# Altium schematic sheet Transistorverstaerker.SchDoc(Transistorverstaerker)
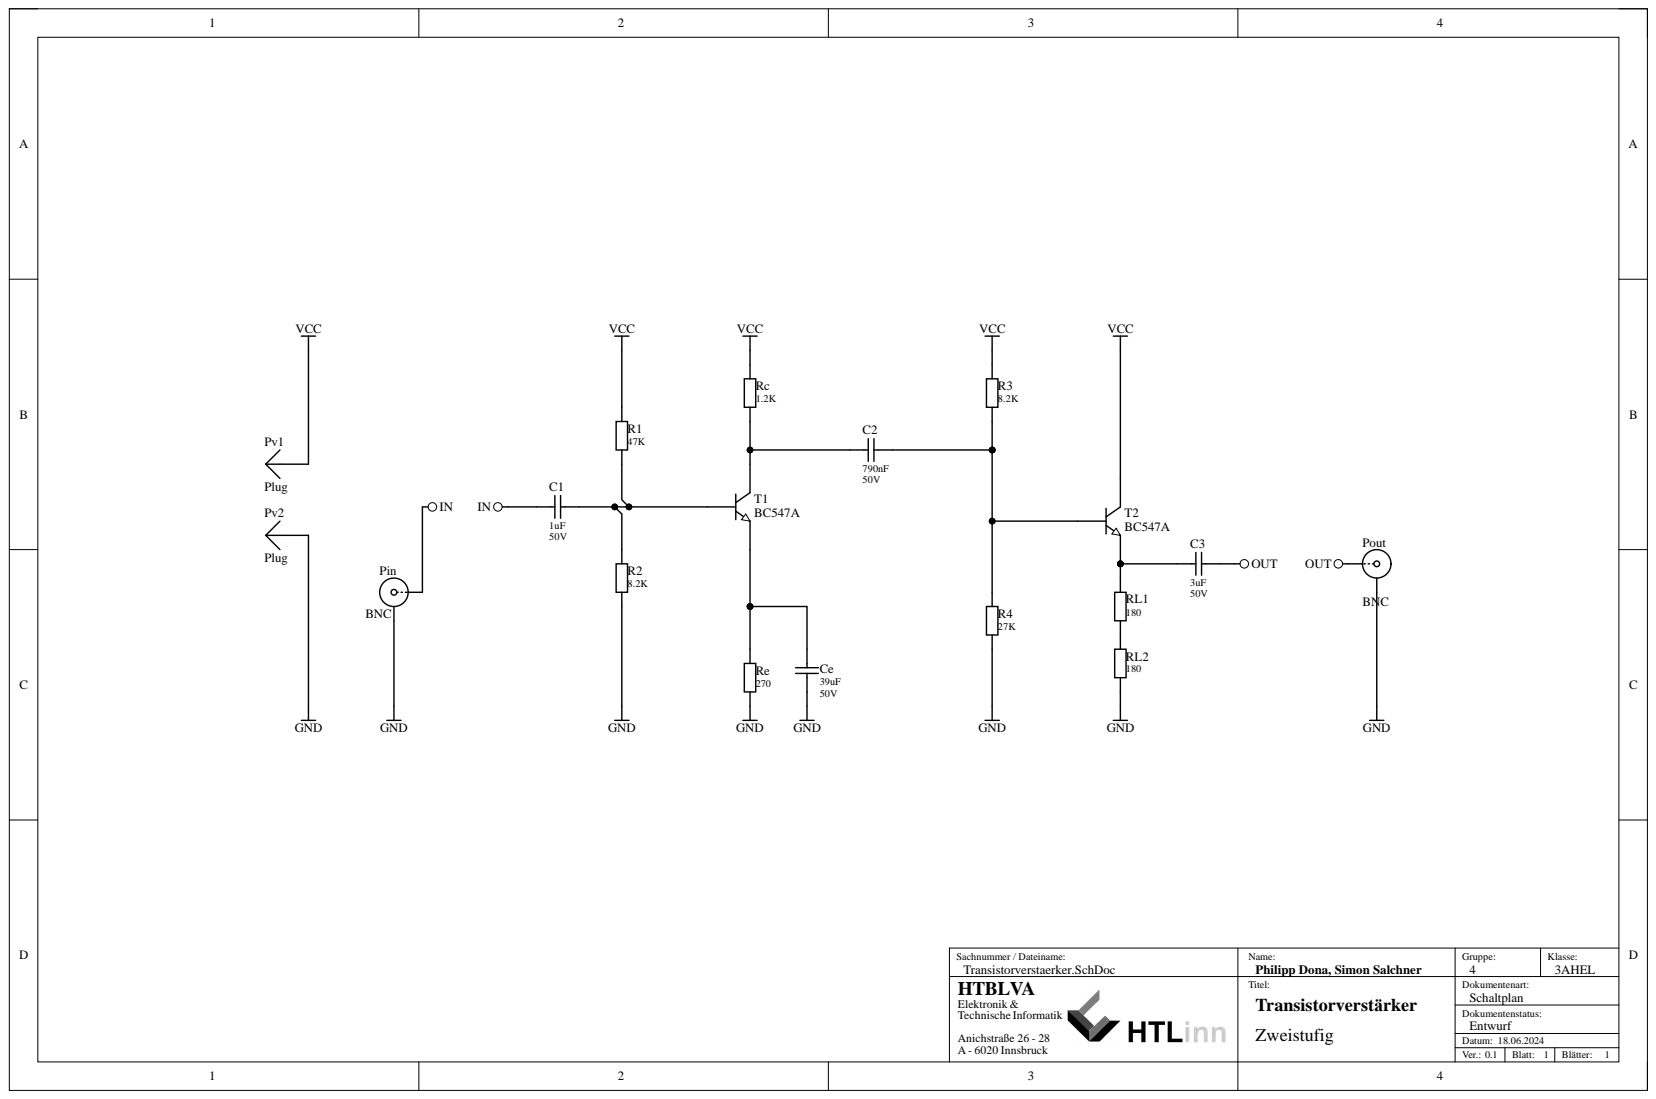
[diagram p0: Transistorverstaerker.SchDoc]

## Parts
C1  1uF  Rated Voltage: 50V  [C_RM2-025X075]
C2  790nF  Rated Voltage: 50V  [C_RM2-025X075]
C3  3uF  Rated Voltage: 50V  [C_RM2-025X075]
Ce  39uF  Rated Voltage: 50V  [C_RM2-025X075]
Pin  BNC  [BNC_RA CON]
Pout  BNC  [BNC_RA CON]
Pv1  Plug  [PIN1]
Pv2  Plug  [PIN1]
R1  47K  [R_0207/RM4]
R2  8.2K  [R_0207/RM4]
R3  8.2K  [R_0207/RM4]
R4  27K  [R_0207/RM4]
Rc  1.2K  [R_0207/RM4]
Re  270  [R_0207/RM4]
RL1  180  [R_0207/RM4]
RL2  180  [R_0207/RM4]
T1  BC547A  [TO-92A-2]
T2  BC547A  [TO-92A-2]

## Nets
GND: Ce.1 Pin.2 Pout.2 Pv2.1 R2.1 R4.1 Re.1 RL2.1
IN: C1.1 Pin.1
OUT: C3.2 Pout.1
VCC: Pv1.1 R1.2 R3.2 Rc.2 T2.3
NetC1_2: C1.2 R1.1 R2.2 T1.2
NetC2_1: C2.1 Rc.1 T1.3
NetC2_2: C2.2 R3.1 R4.2 T2.2
NetC3_1: C3.1 RL1.2 T2.1
NetCe_2: Ce.2 Re.2 T1.1
NetRL1_1: RL1.1 RL2.2

## Library data of the parts
C1-C3 Ce = C: Capacitor; Usage: only permitted for non-commercial purposes at HTL I Innsbruck; Component Kind: Standard; LatestRevisionDate: 07-Sep-2019; Library Name: HTLInn_RLCQ.IntLib; Published: 21-Feb-2011; Signal Integrity: C; Simulation: C
  C1: Value: 1uF
  C2: Value: 790nF
  C3: Value: 3uF
  Ce: Value: 39uF
Pin Pout = BNC: BNC Elbow Connector; Component Kind: Standard; LatestRevisionDate: 17-Jul-2002; LatestRevisionNote: Re-released for DXP Platform.; Library Name: Miscellaneous Connectors.IntLib; Signal Integrity: Connector; Publisher: Altium Limited
R1-R4 Rc Re RL1 RL2 = R: Resistor; Usage: only permitted for non-commercial purposes at HTL I Innsbruck; Component Kind: Standard; LatestRevisionDate: 07-Sep-2019; Library Name: HTLInn_RLCQ.IntLib; Published: 21-Feb-2011; Signal Integrity: R; Simulation: R
  R1: Value: 47K
  R2 R3: Value: 8.2K
  R4: Value: 27K
  Rc: Value: 1.2K
  Re: Value: 270
  RL1 RL2: Value: 180
T1 T2 = BC547A: NPN General-purpose Transistor; SimulationModellSource: Philips Discrete BJT - Low Power; Usage: only permitted for non-commercial purposes at HTL I Innsbruck; Component Kind: Standard; LatestRevisionDate: 31-Oct-2012; Published: 21-Feb-2011; Signal Integrity: BC547A; Simulation: QBC547A; Library Name: HTLinn_BJT.IntLib
Pv1 Pv2 = Plug: Component Kind: Standard; Description: Plug; LatestRevisionDate: 17-Jul-2002; LatestRevisionNote: Re-released for DXP Platform.; Library Name: Miscellaneous Connectors.IntLib; Signal Integrity: Connector; Publisher: Altium Limited
